# Altium schematic sheet GateDriverPowerSupply.SchDoc
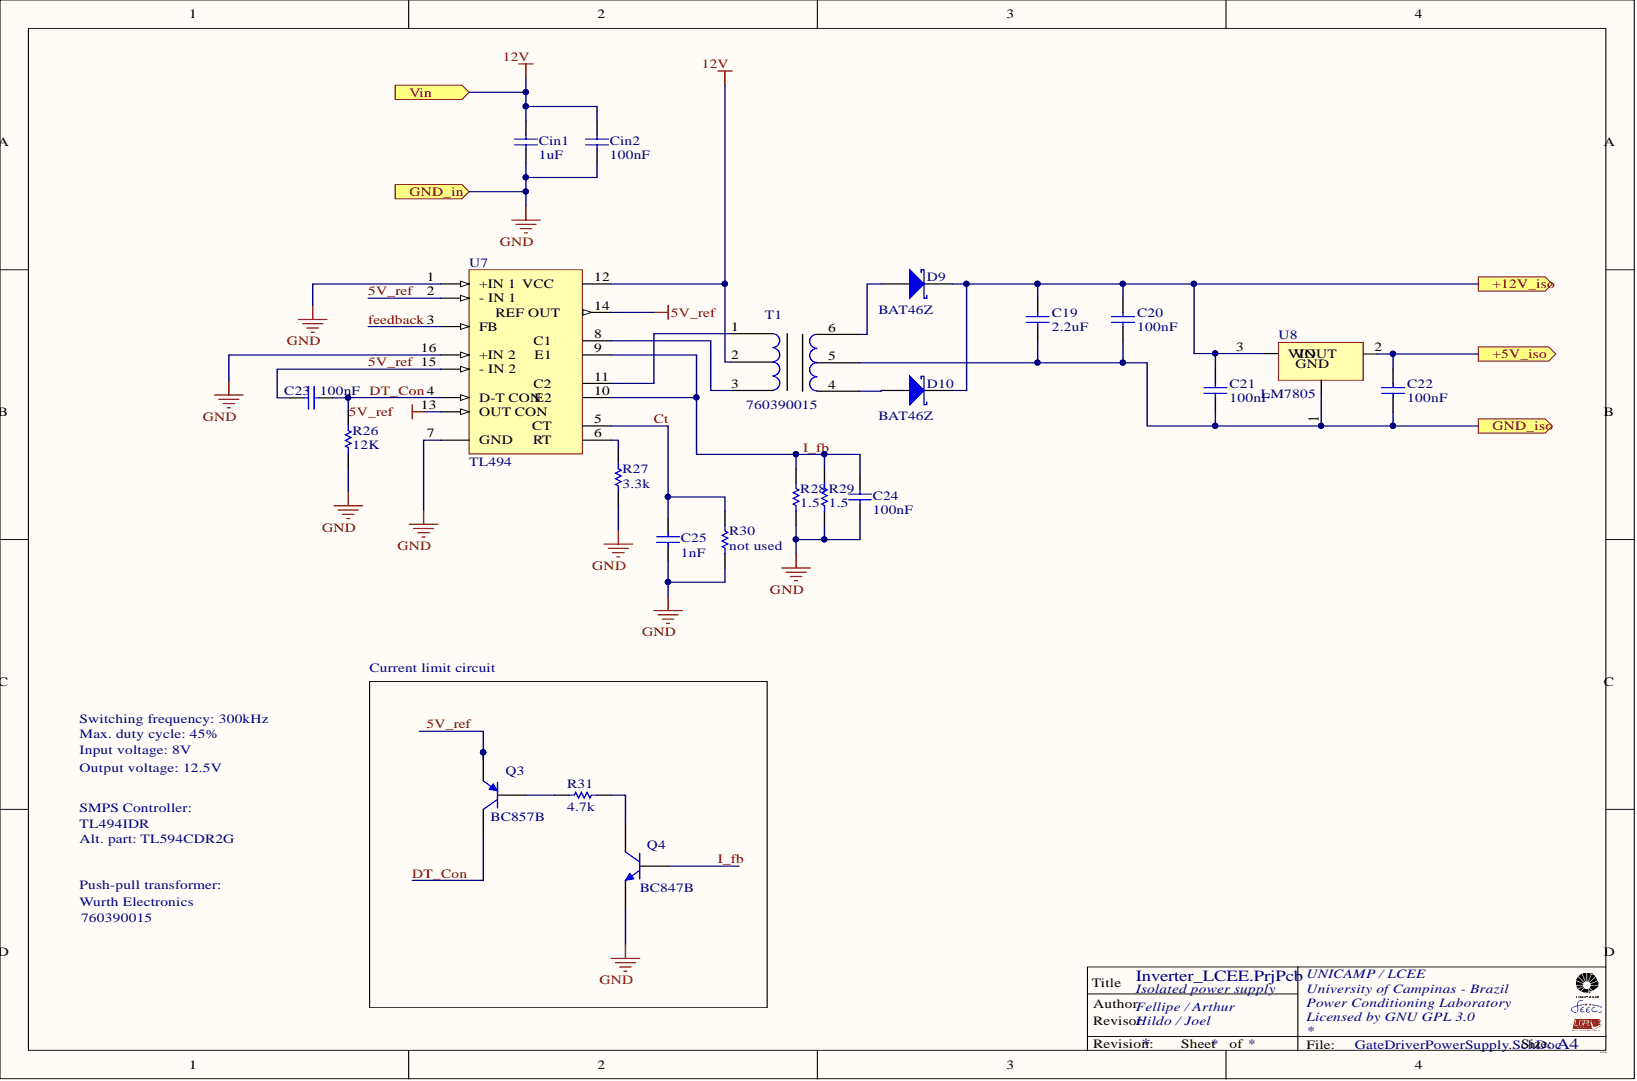
[diagram p0: GateDriverPowerSupply.SchDoc]

## Parts
C19  value 2.2uF  [0805_W]
C20  value 100nF  [0805_W]
C21  value 100nF  [0805_W]
C22  value 100nF  [0805_W]
C23  value 100nF  [0805_W]
C24  value 100nF  [0805_W]
C25  value 1nF  [0805_W]
Cin1  value 1uF  [0805_W]
Cin2  value 100nF  [0805_W]
D9  value BAT46Z  [SOD-123]
D10  value BAT46Z  [SOD-123]
Q3  value BC857B  [SOT-23-TT3_N]
Q4  value BC847B  [SOT-23-TT3_N]
R26  value 12K  [0805_W]
R27  value 3.3k  [0805_W]
R28  value 1.5  [0805_W]
R29  value 1.5  [0805_W]
R30  value not used  [0805_W]
R31  value 4.7k  [0805_W]
T1  value 760390015  [Trafo]
U7  value TL494  [SOIC16_M]
U8  value LM7805  [LM78XX]

## Ports
+5V_ISO, +12V_ISO, GND_IN, GND_ISO, VIN

## Nets
12V: Cin1.1 Cin2.1 T1.2 U7.12 ; port VIN
GND: C24.1 C25.2 Cin1.2 Cin2.2 Q4.2 R26.1 R27.1 R28.1 R29.1 R30.1 U7.1(+IN_1) U7.7(GND) U7.16(+IN_2) ; port GND_IN
5V_REF: C23.1 Q3.2 U7.2(-_IN_1) U7.13(OUT_CON) U7.14(REF_OUT) U7.15(-_IN_2)
CT: C25.1 R30.2 U7.5
DT_CON: C23.2 Q3.3 R26.2 U7.4
FEEDBACK: U7.3(FB)
I_FB: C24.2 Q4.1 R28.2 R29.2 U7.9 U7.10
NetC19_1: C19.1 C20.1 C21.1 D9.2 D10.2 U8.3 ; port +12V_ISO
NetC19_2: C19.2 C20.2 C21.2 C22.2 T1.5 U8.1 ; port GND_ISO
NetC22_1: C22.1 U8.2 ; port +5V_ISO
NetD9_1: D9.1 T1.6
NetD10_1: D10.1 T1.4
NetQ3_1: Q3.1 R31.2
NetQ4_3: Q4.3 R31.1
NetR27_2: R27.2 U7.6
NetT1_1: T1.1 U7.11
NetT1_3: T1.3 U7.8

## Library data of the parts
C19-C22 = Capacitor_nao_polarizado: Capacitor nao polarizado; Component Kind: Standard; Library Name: Sch_LCEE.SchLib
C23-C25 Cin1 Cin2 = Cap_0805: Capacitor; Component Kind: Standard; LatestRevisionDate: 17-Jul-2002; LatestRevisionNote: Re-released for DXP Platform.; PackageReference: RAD-0.3; Published: 8-Jun-2000; Signal Integrity: Cap; Simulation: CAP; Library Name: Inversor_LCEE.SchLib; Publisher: Altium Limited
D9 D10 = D Schottky: Schottky Diode; Code_JEDEC: DO-214-AA; Component Kind: Standard; LatestRevisionDate: 17-Jul-2002; LatestRevisionNote: Re-released for DXP Platform.; Library Name: Miscellaneous Devices.IntLib; PackageDescription: DO-214-AA/SMB; 2 C-Bend Leads; Body 5.3 x 3.6 mm, inc. leads (LxW); PackageReference: SMB; Published: 8-Jun-2000; Publisher: Altium Limited
Q3 = BC857: PNP General-purpose Transistor; Component Kind: Standard; ComponentLink1Description: Manufacturer Link; ComponentLink1URL: http://www.semiconductors.philips.com/; ComponentLink2Description: Datasheet; ComponentLink2URL: http://www.semiconductors.philips.com/acrobat/datasheets/BC856_BC857_BC858_6.pdf; DatasheetVersion: 4-Feb-2002; LatestRevisionDate: 12-Dec-2006; LatestRevisionNote: IPC-7351 Footprint Added.; Library Name: Philips Discrete BJT - Low Power.IntLib; PackageDescription: 3-Pin SOT-23 Package 0.95 mm Pitch, 2.3 mm Lead Span; PackageReference: SOT23; PackageVersion: May-1999; Published: 17-Sep-2003; Signal Integrity: BC857; Simulation: QBC857; Publisher: Altium Limited
Q4 = BC847: NPN General-purpose Transistor; Component Kind: Standard; ComponentLink1Description: Manufacturer Link; ComponentLink1URL: http://www.semiconductors.philips.com/; ComponentLink2Description: Datasheet; ComponentLink2URL: http://www.semiconductors.philips.com/acrobat/datasheets/BC846_BC847_BC848_5.pdf; DatasheetVersion: 4-Feb-2002; LatestRevisionDate: 12-Dec-2006; LatestRevisionNote: IPC-7351 Footprint Added.; Library Name: Philips Discrete BJT - Low Power.IntLib; PackageDescription: 3-Pin SOT-23 Package 0.95 mm Pitch, 2.3 mm Lead Span; PackageReference: SOT23; PackageVersion: May-1999; Published: 17-Sep-2003; Signal Integrity: BC847; Simulation: QBC847; Publisher: Altium Limited
R26-R31 = Res_0805: Resistor; Manufacturer: Generic Components; Class: Passive; Component Kind: Standard; Published: 08/06/2000; Signal Integrity: Res1; Simulation: RESISTOR; SubClass: Resistor; Library Name: Inversor_LCEE.SchLib; Publisher: Altium Hobart Technology Centre
T1 = Trafo-TI: Component Kind: Standard; Library Name: Sch_LCEE.SchLib
U7 = TL494ID: Pulse-Width-Modulation Control Circuit; Code_IPC: SOIC127P600-16; Component Kind: Standard; ComponentLink1Description: Manufacturer Link; ComponentLink1URL: http://www.ti.com/; DatasheetVersion: Oct-1988; LatestRevisionDate: 13-Apr-2006; LatestRevisionNote: IPC-7351 Footprint Added.; Library Name: TI Power Mgt Power Controller.IntLib; PackageDescription: 16-Pin Small Outline Integrated Circuit 1.27 mm Pitch; PackageReference: D016; PackageVersion: Jan-1998; PCB3D: D016; Published: 15-Apr-2002; Publisher: Altium Limited
U8 = LM7805: Component Kind: Standard; Library Name: Sch_LCEE.SchLib
